# Altium schematic sheet Sheet2.SchDoc
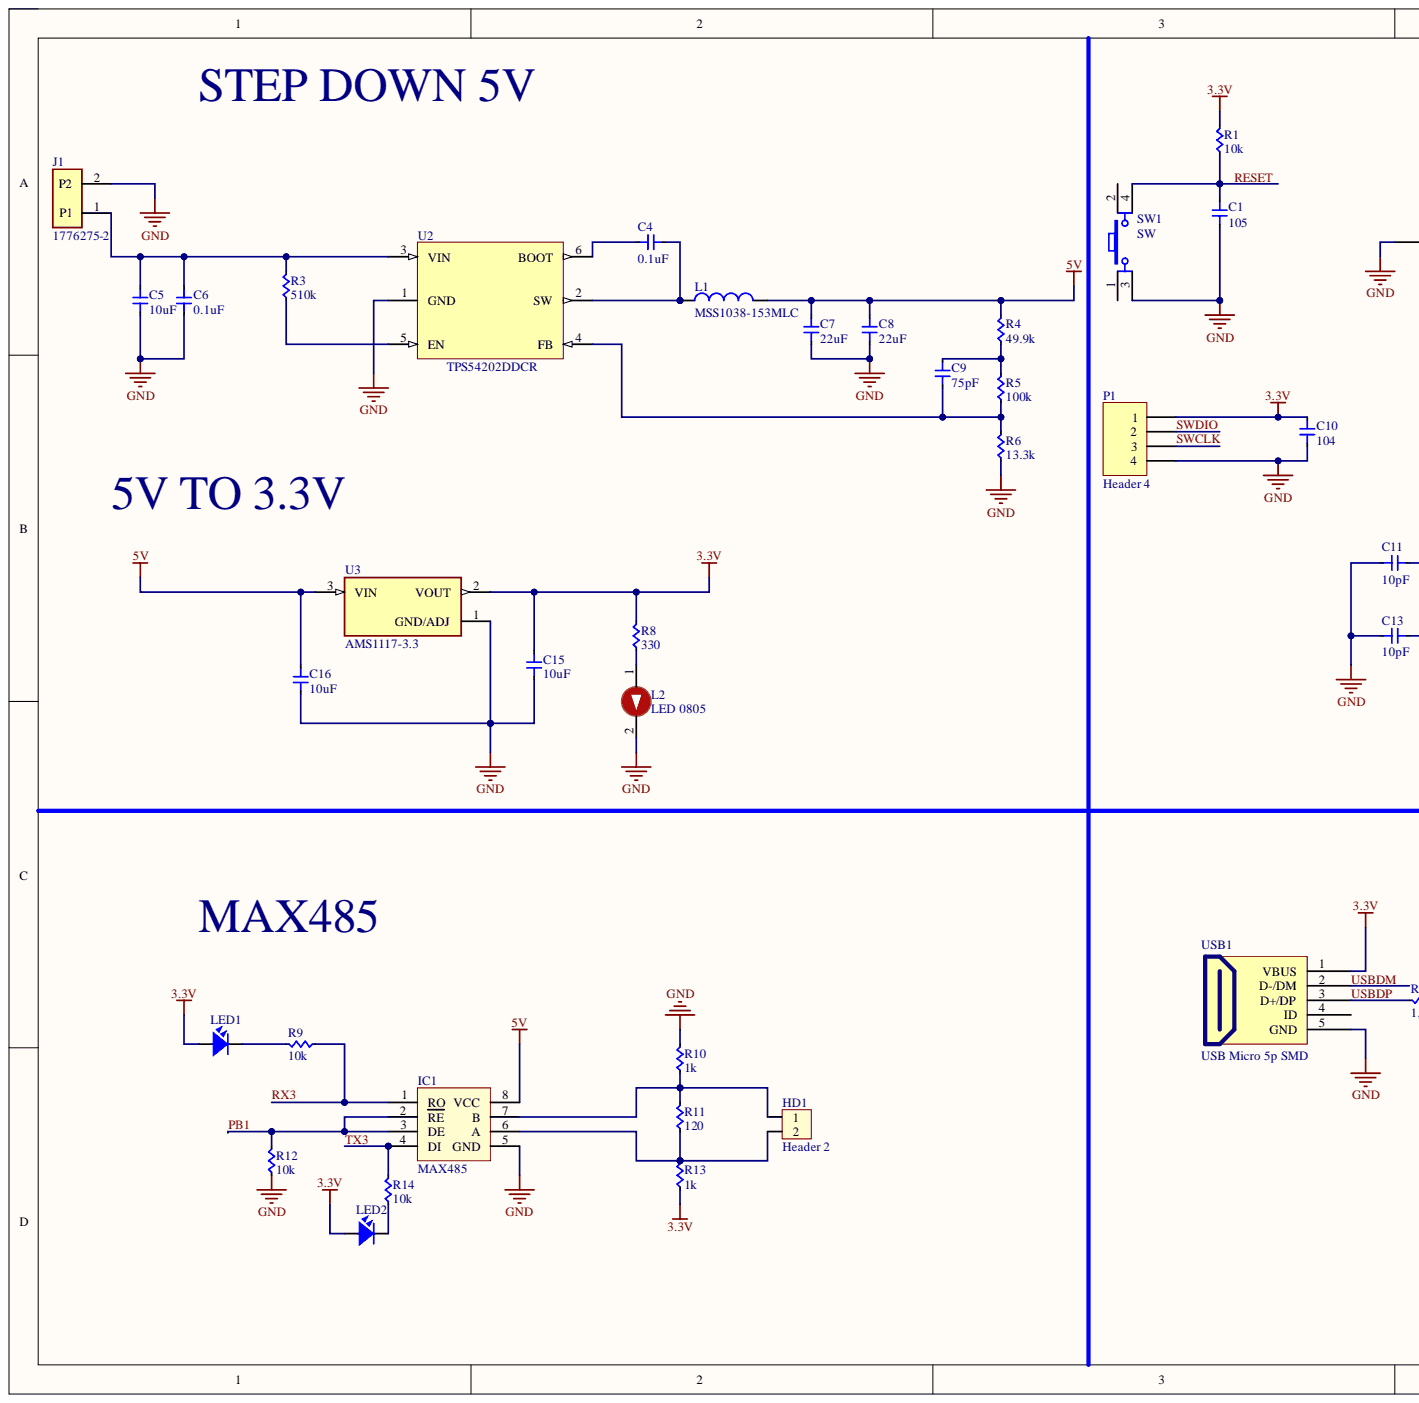
[diagram p0: Sheet2.SchDoc, left]
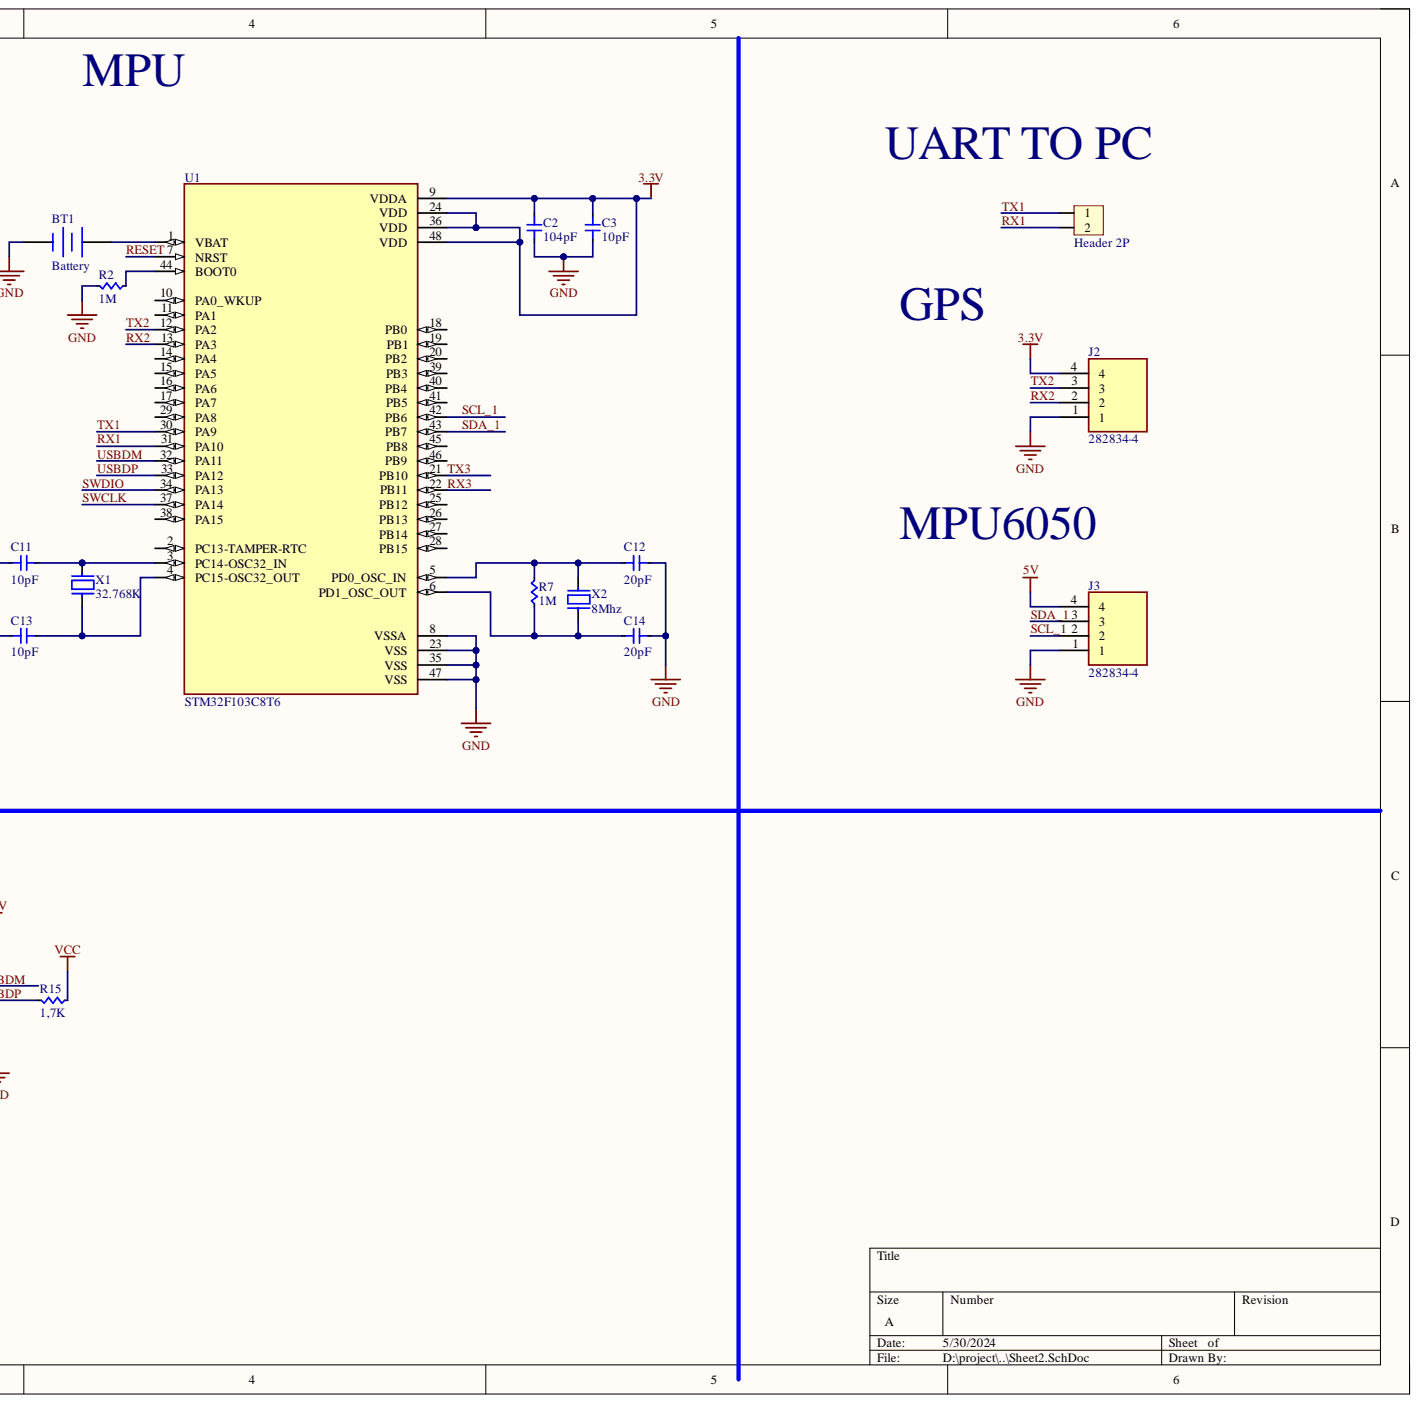
[diagram p1: Sheet2.SchDoc, right]

## Parts
BT1  Battery  [BAT-2]
C1  105  [Cap 0603]
C2  104pF  [Cap 0402]
C3  10pF  [Cap 0402]
C4  0.1uF  [Cap 0402]
C5  10uF  [Cap 0402]
C6  0.1uF  [Cap 0402]
C7  22uF  [Cap 0402]
C8  22uF  [Cap 0402]
C9  75pF  [Cap 0402]
C10  104  [Cap 0603]
C11  10pF  [Cap 0402]
C12  20pF  [Cap 0402]
C13  10pF  [Cap 0402]
C14  20pF  [Cap 0402]
C15  10uF  [Cap 0402]
C16  10uF  [Cap 0402]
H1  Header 2P  [XH2.54M-2]
HD1  Header 2  [HED2]
IC1  MAX485  [SO-8]
J1  1776275-2  [TE_1776275-2]
J2  282834-4  [TE_282834-4]
J3  282834-4  [TE_282834-4]
L1  MSS1038-153MLC  [IND_370_401_140X70_SMD]
L2  LED 0805  [LED-0805-Red]
LED1  LED  [LED-3]
LED2  LED  [LED-3]
P1  Header 4  [HDR1X4]
R1  10k  [RES 0603]
R2  1M  [RES 0603]
R3  510k  [RES 0603]
R4  49.9k  [RES 0603]
R5  100k  [RES 0603]
R6  13.3k  [RES 0603]
R7  1M  [RES 0603]
R8  330  [RES 0603]
R9  10k  [RES 0603]
R10  1k  [RES 0603]
R11  120  [RES 0603]
R12  10k  [RES 0603]
R13  1k  [RES 0603]
R14  10k  [RES 0603]
R15  1,7K  [RES 0603]
SW1  SW  [SW4]
U1  STM32F103C8T6  [QFP50P900X900X160-48N]
U2  TPS54202DDCR  [SOT95P280X110-6N]
U3  AMS1117-3.3  [SOT229P700X180-4N]
USB1  USB Micro 5p SMD  [USB Micro 5p SMD]
X1  32.768K  [XT2]
X2  8Mhz  [XT2]

## Nets
3.3V: C2.2 C3.2 C10.1 C15.1 J2.4 LED1.1 LED2.1 P1.1 R1.2 R8.2 R13.1 U1.9(VDDA) U1.24(VDD) U1.36(VDD) U1.48(VDD) U3.2 USB1.1(VBUS)
5V: C7.1 C8.1 C16.1 IC1.8(VCC) J3.4 L1.2 R4.2 U3.3
GND: BT1.2 C1.2 C2.1 C3.1 C5.2 C6.2 C7.2 C8.2 C10.2 C11.1 C12.2 C13.1 C14.2 C15.2 C16.2 IC1.5(GND) J1.2 J2.1 J3.1 L2.2 P1.4 R2.2 R6.1 R10.2 R12.1 SW1.3 U1.8(VSSA) U1.23(VSS) U1.35(VSS) U1.47(VSS) U2.1(GND) U3.1 USB1.5(GND)
VCC: R15.1
PB1: IC1.2(RE) IC1.3(DE) R12.2
RESET: C1.1 R1.1 SW1.4 U1.7(NRST)
RX1: H1.2 U1.31(PA10)
RX2: J2.2 U1.13(PA3)
RX3: IC1.1(RO) R9.1 U1.22(PB11)
SCL_1: J3.2 U1.42(PB6)
SDA_1: J3.3 U1.43(PB7)
SWCLK: P1.3 U1.37(PA14)
SWDIO: P1.2 U1.34(PA13)
TX1: H1.1 U1.30(PA9)
TX2: J2.3 U1.12(PA2)
TX3: IC1.4(DI) R14.2 U1.21(PB10)
USBDM: U1.32(PA11) USB1.2(D-/DM)
USBDP: R15.2 U1.33(PA12) USB1.3(D+/DP)
NetBT1_1: BT1.1 U1.1(VBAT)
NetC4_1: C4.1 U2.6(BOOT)
NetC4_2: C4.2 L1.1 U2.2(SW)
NetC5_1: C5.1 C6.1 J1.1 R3.2 U2.3(VIN)
NetC9_1: C9.1 R4.1 R5.2
NetC9_2: C9.2 R5.1 R6.2 U2.4(FB)
NetC11_2: C11.2 U1.3(PC14-OSC32_IN) X1.2
NetC12_1: C12.1 R7.2 U1.5(PD0_OSC_IN) X2.2
NetC13_2: C13.2 U1.4(PC15-OSC32_OUT) X1.1
NetC14_1: C14.1 R7.1 U1.6(PD1_OSC_OUT) X2.1
NetHD1_1: HD1.1 IC1.7(B) R10.1 R11.2
NetHD1_2: HD1.2 IC1.6(A) R11.1 R13.2
NetL2_1: L2.1 R8.1
NetLED1_2: LED1.2 R9.2
NetLED2_2: LED2.2 R14.1
NetR2_1: R2.1 U1.44(BOOT0)
NetR3_1: R3.1 U2.5(EN)

Not connected: SW1 1 2; U1 2(PC13-TAMPER-RTC) 10(PA0_WKUP) 11(PA1) 14(PA4) 15(PA5) 16(PA6) 17(PA7) 18(PB0) 19(PB1) 20(PB2) 25(PB12) 26(PB13) 27(PB14) 28(PB15) 29(PA8) 38(PA15) 39(PB3) 40(PB4) 41(PB5) 45(PB8) 46(PB9); USB1 4(ID)

## Library data of the parts
BT1 = Battery: Multicell Battery; Component Kind: Standard; LatestRevisionDate: 17-Jul-2002; LatestRevisionNote: Re-released for DXP Platform.; Library Name: Miscellaneous Devices.IntLib; PackageDescription: Battery; 2 Leads; PackageReference: BAT-2; Published: 24-Mar-1999; Publisher: Altium Limited
C1 C10 = Cap 0603: Capacitor SMD 0603; Component Kind: Standard; Library Name: Altium_Lib_by_KhueNguyenCreator.IntLib
C2-C9 C11-C16 = Cap 0402: Capacitor SMD 0402; Component Kind: Standard; Library Name: Altium_Lib_by_KhueNguyenCreator.IntLib
H1 = Header 2P 2.54 Duc Thang: Cắm; Don_Vi: Con; Ma_Hang: MH001283; Ten_Linh_Kien: Header 2P XH2.54-2P Đực Thẳng; Chung_Loai: Cắm; Component Kind: Standard; Library Name: Altium_Lib_by_KhueNguyenCreator.IntLib
HD1 = Hed2: Header 2 (Green); Component Kind: Standard; Value: Header 2; Library Name: syhaunguyen.SchLib
IC1 = MAX485: RS232 - RS485 (Same sn75176); Component Kind: Standard; Library Name: VioletofSun.SchLib
J1 = 1776275-2: 2 Position Wire to Board Terminal Block Horizontal with Board 0.138" (3.50mm) Through Hole; MF: TE Connectivity; Availability: Unavailable; Check_prices: https://www.snapeda.com/parts/1776275-2/TE+Connectivity+AMP+Connectors/view-part/?ref=eda; Component Kind: Standard; Library Name: 1776275-2.IntLib; MP: 1776275-2; Package: None; Price: None; SnapEDA_Link: https://www.snapeda.com/parts/1776275-2/TE+Connectivity+AMP+Connectors/view-part/?ref=snap
J2 J3 = 282834-4: 4 Position Wire to Board Terminal Block Horizontal with Board 0.100 (2.54mm) Through Hole; MF: TE Connectivity; Availability: In Stock; Check_prices: https://www.snapeda.com/parts/282834-4/TE+Connectivity+AMP+Connectors/view-part/?ref=eda; Component Kind: Standard; EU_RoHS_Compliance: Compliant with Exemptions; Library Name: 282834-4.IntLib; MP: 282834-4; Package: None; Price: None; Purchase-URL: https://pricing.snapeda.com/search/part/282834-4/?ref=eda; SnapEDA_Link: https://www.snapeda.com/parts/282834-4/TE+Connectivity+AMP+Connectors/view-part/?ref=snap
L1 = IND_15uH-3.8A-30%-SMD: Fixed Inductors MSS1038 SMT Shielded 15 uH 20 % 3.8 A; Alternate Part Number-1: NA; Manufacturer: Bourns; Case/Package: SMD; Component Kind: Standard; Manufacturer Part Number: MSS1038-153MLC; Supplier Part Number-1: MSS1038-153MLC; Supplier-1: COILCRAFT SAMPLE; Library Name: Altium_Lib_by_KhueNguyenCreator.IntLib
L2 = LED 0805 Do: Dán; Don_Vi: Con; Ma_Hang: MH000897; Ten_Linh_Kien: LED 0805 Màu Đỏ (Red); Chung_Loai: Dán; Component Kind: Standard; Library Name: Altium_Lib_by_KhueNguyenCreator.IntLib
LED1 LED2 = LED: LED Single colour Green and Red; Value: LED 3 ly Single Colour; Component Kind: Standard; Library Name: syhaunguyen.SchLib
P1 = Header 4: Header, 4-Pin; Component Kind: Standard; LatestRevisionDate: 17-Jul-2002; LatestRevisionNote: Re-released for DXP Platform.; Library Name: Miscellaneous Connectors.IntLib; Signal Integrity: Connector; Publisher: Altium Limited
R1 R9 R12 R14 = RES 0603: RES SMD 0603; Manufacturer: Vishay Dale; Manufacturer Part Number: CRCW040210K0FKED; Power (Watts): 0.063W, 1/16W; Temperature Coefficient: ±100ppm/°C; Tolerance: ±1%; Category: Resistors; Component Kind: Standard; ComponentLink1Description: http://www.vishay.com/docs/20035/dcrcwe3.pdf; ComponentLink1URL: http://www.vishay.com/docs/20035/dcrcwe3.pdf; Composition: Thick Film; Features: Automotive AEC-Q200; Height: 0.016" (0.40mm); Number of Terminations: 2; Operating Temperature: -55°C ~ 155°C; Package / Case: 0402 (1005 Metric); Packaging: Cut Tape (CT); Pricing 1: 10=0.083, 50=0.0444, 200=0.0255, 1000=0.0174 (USD); Resistance (Ohms): 10k; RoHS: RoHS Compliant; Size / Dimension: 0.039" L x 0.020" W (1.00mm x 0.50mm); Stock 1: 2392462; Supplier 1: Digi-Key; Supplier Device Package: 0402 (1005 Metric); Supplier Part Number 1: 541-10.0KLCT-ND; Library Name: Altium_Lib_by_KhueNguyenCreator.IntLib
R2-R8 R10 R11 R13 R15 = RES 0603: RES SMD 0603; Manufacturer: Vishay Dale; Manufacturer Part Number: CRCW040210K0FKED; Power (Watts): 0.063W, 1/16W; Resistance (Ohms): 10k; Temperature Coefficient: ±100ppm/°C; Tolerance: ±1%; Category: Resistors; Component Kind: Standard; ComponentLink1Description: http://www.vishay.com/docs/20035/dcrcwe3.pdf; ComponentLink1URL: http://www.vishay.com/docs/20035/dcrcwe3.pdf; Composition: Thick Film; Features: Automotive AEC-Q200; Height: 0.016" (0.40mm); Number of Terminations: 2; Operating Temperature: -55°C ~ 155°C; Package / Case: 0402 (1005 Metric); Packaging: Cut Tape (CT); Pricing 1: 10=0.083, 50=0.0444, 200=0.0255, 1000=0.0174 (USD); RoHS: RoHS Compliant; Size / Dimension: 0.039" L x 0.020" W (1.00mm x 0.50mm); Stock 1: 2392462; Supplier 1: Digi-Key; Supplier Device Package: 0402 (1005 Metric); Supplier Part Number 1: 541-10.0KLCT-ND; Library Name: Altium_Lib_by_KhueNguyenCreator.IntLib
SW1 = SW4: Switch button 4 pin; Value: Button chip 4 pin; Component Kind: Standard; Library Name: syhaunguyen.SchLib
U1 = STM32F103C8T6: ARM® Cortex®-M3 STM32F1 Microcontroller IC 32-Bit 72MHz 64KB (64K x 8) FLASH 48-LQFP (7x7); MF: STMicroelectronics; Check_prices: https://www.snapeda.com/parts/STM32F103C8T6/STMicroelectronics/view-part/?ref=eda; Component Kind: Standard; Library Name: STM32F103C8T6.IntLib; MP: STM32F103C8T6; Package: LQFP-48 STMicroelectronics; SnapEDA_Link: https://www.snapeda.com/parts/STM32F103C8T6/STMicroelectronics/view-part/?ref=snap
U2 = TPS54202DDCR: 4.5V to 28V Input, 2A Output, EMI Friendly Synchronous Step-Down Converter 6-SOT-23-THIN -40 to 125; MF: Texas Instruments; Availability: Good; BuyPart: https://www.snapeda.com/parts/TPS54202DDCR/Texas%20Instruments/view-part/565860/?ref=eda; Component Kind: Standard; DigiKey-Purchase-URL: https://snapeda.com/shop?store=DigiKey&id=565860; Library Name: TPS54202DDCR.SchLib; Mouser-Purchase-URL: https://snapeda.com/shop?store=Mouser&id=565860; MP: TPS54202DDCR; Package: SOT-23-THN-6 Texas Instruments; Price: None; SnapEDA_Link: https://www.snapeda.com/parts/TPS54202DDCR/Texas%20Instruments/view-part/565860/?ref=snap; Texas_Instruments-Purchase-URL: https://snapeda.com/shop?store=Texas+Instruments&id=565860
U3 = AMS1117-3.3: Sot223/Pkg 1-Amp 3.3-Volt Low Drop Out Voltage Regulatator; MF: Advanced Monolithic Systems; Availability: Not in stock; Check_prices: https://www.snapeda.com/parts/AMS1117-3.3/Advanced+Monolithic+Systems/view-part/?ref=eda; Component Kind: Standard; Library Name: AMS1117-3.3.IntLib; MP: AMS1117-3.3; Package: SOT-223 Seeed Technology; Price: None; SnapEDA_Link: https://www.snapeda.com/parts/AMS1117-3.3/Advanced+Monolithic+Systems/view-part/?ref=snap
USB1 = USB Micro 5p SMD: USB Type B; Ma_Hang: MH001020; Ten: MicroUSB MK5P SMD; Component Kind: Standard; Library Name: Altium_Lib_by_KhueNguyenCreator.IntLib
X1 X2 = XT2: Crystal For all Crystal 2pin.; Value: 4Mhz; Component Kind: Standard; Library Name: VioletofSun.SchLib
